# Altium schematic sheet H05R2x_Frontend 1.SchDoc("H05R2x_Frontend 1")
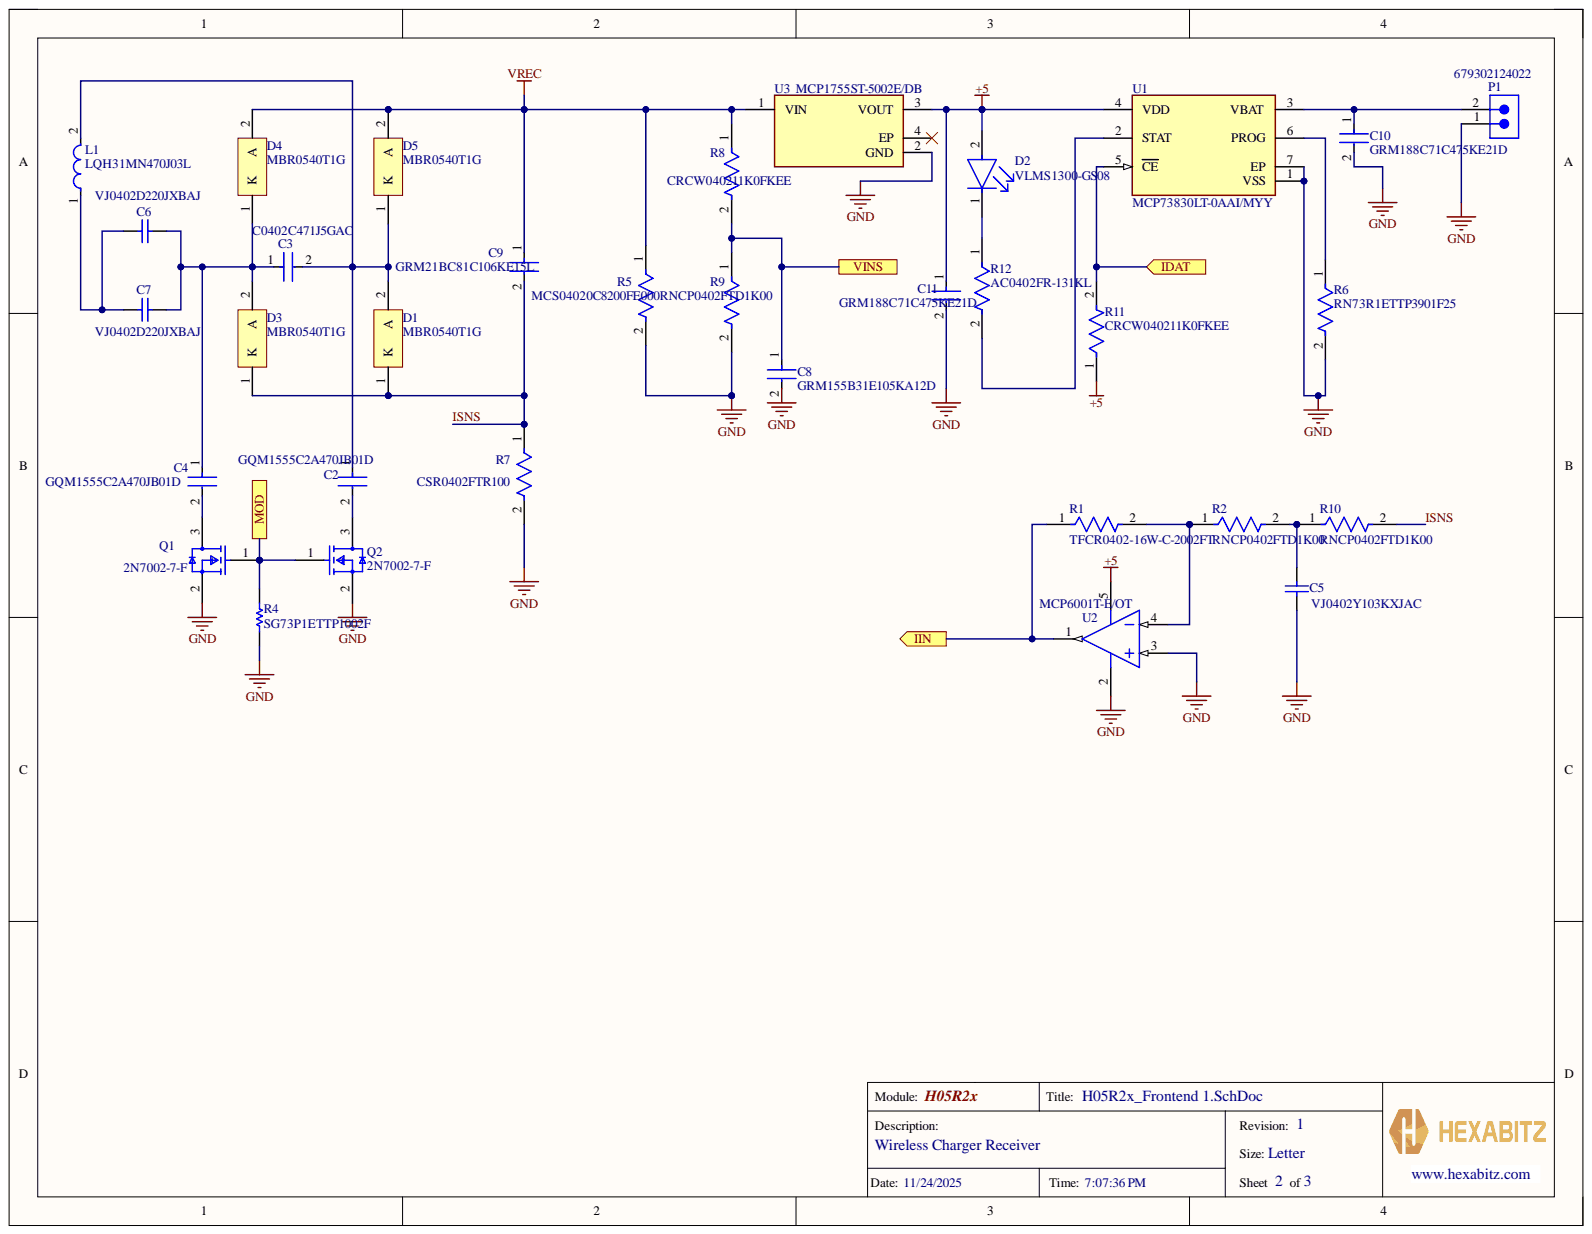
[diagram p0: H05R2x_Frontend 1.SchDoc]

## Parts
C2  GQM1555C2A470JB01D  [FP-GQM155-0_05-IPC_A]
C3  C0402C471J5GAC  [FP-C0402-BB-MFG]
C4  GQM1555C2A470JB01D  [FP-GQM155-0_05-IPC_A]
C5  VJ0402Y103KXJAC  [CAPC1005X60X26LL10T16]
C6  VJ0402D220JXBAJ  [CAPC1005X61X26LL10T16]
C7  VJ0402D220JXBAJ  [CAPC1005X61X26LL10T16]
C8  GRM155B31E105KA12D  [FP-GRM155-0_05-IPC_C]
C9  GRM21BC81C106KE15L  [FP-GRM21B-0_1-IPC_B]
C10  GRM188C71C475KE21D  [FP-GRM188-0_2-IPC_A]
C11  GRM188C71C475KE21D  [FP-GRM188-0_2-IPC_A]
D1  MBR0540T1G  [ONSC-SOD-123-2-425-04_V]
D2  VLMS1300-GS08  [FP-6_541-5092_01-4-MFG]
D3  MBR0540T1G  [ONSC-SOD-123-2-425-04_V]
D4  MBR0540T1G  [ONSC-SOD-123-2-425-04_V]
D5  MBR0540T1G  [ONSC-SOD-123-2-425-04_V]
L1  LQH31MN470J03L  [FP-LQH31CN_03-MFG]
P1  679302124022  [679302124022]
Q1  2N7002-7-F  [FP-SOT23-MFG]
Q2  2N7002-7-F  [FP-SOT23-MFG]
R1  TFCR0402-16W-C-2002FT  [FP-TFCR0402-IPC_A]
R2  RNCP0402FTD1K00  [FP-RNCP0402-IPC_C]
R4  SG73P1ETTP1002F  [RESC1005X40X25LL10T10]
R5  MCS04020C8200FE000  [FP-MCS0402-IPC_C]
R6  RN73R1ETTP3901F25  [FP-RN73R1E-IPC_C]
R7  CSR0402FTR100  [FP-CSR0402-IPC_A]
R8  CRCW040211K0FKEE  [FP-CRCW0402-e3-IPC_B]
R9  RNCP0402FTD1K00  [FP-RNCP0402-IPC_C]
R10  RNCP0402FTD1K00  [FP-RNCP0402-IPC_C]
R11  CRCW040211K0FKEE  [FP-CRCW0402-e3-IPC_B]
R12  AC0402FR-131KL  [FP-AC0402-IPC_C]
U1  MCP73830LT-0AAI/MYY  [FP-C04-078-IPC_C]
U2  MCP6001T-E/OT  [SOT-23-OT5_L]
U3  MCP1755ST-5002E/DB  [FP-C04-032-IPC_B]

## Ports
IDAT, IIN, MOD, VINS

## Nets
+5: C11.1 D2.2 R11.1 U1.4(VDD) U2.5 U3.3(VOUT)
GND: C5.2 C8.2 C10.2 C11.2 P1.1 Q1.2 Q2.2 R4.1 R5.2 R6.2 R7.2 R9.2 U1.1(VSS) U1.7(EP) U2.2 U2.3 U3.2(GND)
VREC: C9.1 D4.2 D5.2 R5.1 R8.1 U3.1(VIN)
IDAT: R11.2 U1.5(CE) ; port IDAT
IIN: R1.1 U2.1 ; port IIN
ISNS: C9.2 D1.1 D3.1 R7.1 R10.2
MOD: Q1.1 Q2.1 R4.2 ; port MOD
VINS: C8.1 R8.2 R9.1 ; port VINS
NetC2_1: C2.1 C3.2 D1.2 D5.1 L1.2
NetC2_2: C2.2 Q2.3
NetC3_1: C3.1 C4.1 C6.2 C7.2 D3.2 D4.1
NetC4_2: C4.2 Q1.3
NetC5_1: C5.1 R2.2 R10.1
NetC6_1: C6.1 C7.1 L1.1
NetC10_1: C10.1 P1.2 U1.3(VBAT)
NetD2_1: D2.1 R12.1
NetR1_2: R1.2 R2.1 U2.4
NetR6_1: R6.1 U1.6(PROG)
NetR12_2: R12.2 U1.2(STAT)

Not connected: U3 4(EP)

## Library data of the parts
C2 C4 = CMP-06035-010628-1: Ceramic Capacitor 47pF  ±5% 100VDC C0G 0402 Paper T/R; Voltage Rating: 100V; Capacitance: 47pF; Case/Package: 0402; Component Kind: Standard; Dielectric: C0G; Length: 0.039inch; Library Name: Altium Content Vault; Max Operating Temperature: 125°C; Min Operating Temperature: -55°C; Mount: Surface Mount; Packaging: Tape and Reel; Thickness: 0.022inch; Tolerance: 5%; Voltage Rating (DC): 100V; Width: 0.02inch
C3 = CMP-03020-013778-2: C0402 470 pF C0G 0.05 50 V; Manufacturer: Kemet; Capacitance: 470 pF; Material: Ceramic; Tolerance: 0.05; Value: 470 pF; Voltage Rating: 50 V; Automotive: No; Category: Cap; Component Kind: Standard; ComponentLink2Description: Datasheet; ComponentLink2URL: https://content.kemet.com/datasheets/KEM_C1002_X7R_SMD.pdf; Device Class L1: Passive Components; Device Class L2: Capacitors; Device Class L3: Ceramic Capacitors; Height: 0.55mm; Ipc Land Pattern Name: CAPC10050X50; Lead Free: Yes; Library Name: Altium Content Vault; Manufacturer Part Number: C0402C471J5GAC; Mouser Description: Cap Ceramic 470pF 50V C0G 5% Pad SMD 0402 125C Bulk; Octopart: https://octopart.com/c0402c471j5gac-kemet-29626191; Package: C0402-BB; RoHS: TRUE; Temperature: -55°C to +125°C; Temperature Characteristic: C0G; Temperature Range High: +125°C; Temperature Range Low: -55°C
C5 = CMP-2008-02025-1: Manufacturer: Vitramon; Component Kind: Standard; Library Name: Altium Content Vault; Part Number: VJ0402Y103KXJAC
C6 C7 = CMP-2008-02000-1: Manufacturer: Vitramon; Component Kind: Standard; Library Name: Altium Content Vault; Part Number: VJ0402D220JXBAJ
C8 = CMP-06035-057649-1: Chip Multilayer Ceramic Capacitors for General Purpose, 0402, 1.0uF, B, 10%, 10%, 25V; Capacitance: 1uF; Component Kind: Standard; Length: 1mm; Library Name: Altium Content Vault; Thickness: 500um; Tolerance: 10%; Voltage Rating (DC): 25V; Width: 500um
C9 = CMP-2007-03503-2: Chip Multilayer Ceramic Capacitors for General Purpose, 0805, 10uF, X6S, 22%, 10%, 16V; Capacitance: 10uF; Case Code (Imperial): 0805; Case Code (Metric): 2012; Case/Package: 0805; Component Kind: Standard; Depth: 1.25mm; Dielectric: X6S; Height: 1.25mm; Lead Free: Lead Free; Length: 2mm; Library Name: Altium Content Vault; Max Operating Temperature: 105°C; Min Operating Temperature: -55°C; Mount: Surface Mount; Package Quantity: 3000; Packaging: Tape and Reel; REACH SVHC: No SVHC; Termination: SMD/SMT; Thickness: 0.053inch; Tolerance: 10%; Voltage: 16V; Voltage Rating: 16V; Voltage Rating (DC): 16V; Weight: 0.000335oz; Width: 0.049inch
C10 C11 = CMP-06035-002362-1: Chip Multilayer Ceramic Capacitors for General Purpose, 0603, 4.7uF, X7S, 22%, 10%, 16V; Capacitance: 4.7uF; Component Kind: Standard; Dielectric: X7S; Length: 1.6mm; Library Name: Altium Content Vault; Thickness: 800um; Tolerance: 10%; Voltage Rating (DC): 16V; Width: 800um
D1 D3-D5 = CMP-1055-00401-1: Schottky Power Rectifier, 2-Pin SOD-123, Pb-Free, Tape and Reel; Manufacturer: On Semiconductor; Configuration: Single; IFSM Max (A): 5.5; Component Kind: Standard; ComponentLink1Description: Manufacturer URL; ComponentLink1URL: http://www.onsemi.com/; ComponentLink2Description: Datasheet; ComponentLink2URL: http://www.onsemi.cn/pub_link/Collateral/MBR0540T1-D.PDF; ComponentLink3Description: Package Specification; ComponentLink3URL: http://www.onsemi.com/pub_link/Collateral/425-04.PDF; DatasheetVersion: Rev. 7; Library Name: Altium Content Vault; Mounting Technology: Surface Mount; PackageDescription: 2-Pin SOD, Body 1.6 x 2.69 mm; PackageReference: SOD-123-2-425-04; PackageVersion: Rev. G, 10/2009; Packing: Tape and Reel; PartNumber: MBR0540T1G; RoHS: TRUE
D2 = CMP-02520-000012-1: LED RED 0603 SMD; Manufacturer: Vishay; Forward Current: 20mA; Forward Voltage: 2V; Led Orientation: Top View; Luminous Intensity: 54mcd; Peak Emmision Wavelength: 639nm; Power Dissipation: 75mW; Reverse Voltage: 5V; Viewing Angle: 130°; Wavelength: 631nm; Ambient Temperature Range High: +85°C; Ambient Temperature Range Low: -35°C; Automotive: No; Category: Diode; Colour: Red; Component Kind: Standard; ComponentLink2Description: Datasheet; ComponentLink2URL: http://www.vishay.com/docs/82437/vlmo1300.pdf; Device Class L1: Optoelectronics; Device Class L2: LEDs; Device Class L3: unset; Digikey Description: LED RED 0603 SMD; Height: 1mm; Lead Free: Yes; Library Name: Altium Content Vault; Manufacturer Part Number: VLMS1300-GS08; Mouser Description: Standard LEDs - SMD Super Red Clear Non-Diff; Octopart: https://octopart.com/vlms1300-gs08-vishay-21709201; Package: 6.541-5092.01-4; RoHS: TRUE; Temperature: -35°C to +85°C; Temperature Range High: +85°C; Temperature Range Low: -35°C
L1 = CMP-06042-003344-1: Wire Wound Ferrite Inductor for General Circuits 47μH ±5% 10.4Ω 55mA 1206; DC Resistance (DCR): 8R; Inductance: 47uH; Q Factor: 40; Self Resonant Frequency: 10MHz; Shielding: Unshielded; Test Frequency: 1MHz; Case Code (Imperial): 1206; Case Code (Metric): 3216; Case/Package: 1206; Component Kind: Standard; Core Material: Ferrite; Current Rating: 55mA; DC Current: 55mA; Depth: 1.8mm; Height: 1.8mm; Height - Seated (Max): 0.079inch; Length: 0.126inch; Library Name: Altium Content Vault; Max DC Current: 55mA; Max Operating Temperature: 85°C; Military Standard: Not; Min Operating Temperature: -40°C; Mount: Surface Mount; Package Quantity: 2000; Packaging: Tape and Reel; Radiation Hardening: No; Resistor Type: Wirewound; Termination: SMD/SMT; Tolerance: 5%; Weight: 0.001023oz; Width: 0.063inch
P1 = CMP-1502-00721-2: NexarPartId: 18818281; Connector Type: Male Pin; Contact Resistance: 20mΩ; Current Rating: 1A; Gender: Male; Insulation: Insulated; Insulation Resistance: 100MΩ; Number of Contacts: 2; Operating Supply Voltage: 50V; Pitch: 1.5mm; Color: Beige, White; Component Kind: Standard; Contact Gender: Male; Contact Material: Copper; Contact Plating: Tin; Flammability Rating: UL94 V-0; Height: 5mm; Housing Material: Nylon; Lead Pitch: 1.5mm; Length: 6mm; Library Name: Altium Content Vault; Max Operating Temperature: 85°C; Min Operating Temperature: -25°C; Mount: Surface Mount; Number of Pins: 2; Number of Positions: 2; Number of Rows: 1; Orientation: Vertical; Packaging: Tape and Reel; REACH SVHC: Unknown; RoHS: Compliant; Termination: SMD/SMT; Voltage Rating: 50V; Width: 6mm
Q1 Q2 = CMP-2000-04929-2: MOSFET N-CH 60V 115MA SOT23-3; Drain to Source Breakdown Voltage: 70V; Drain to Source Resistance: 7.5R; Element Configuration: Single; Fall Time: 5.6ns; Gate to Source Voltage (Vgs): 20V; Input Capacitance: 50pF; Max Power Dissipation: 300mW; Nominal Vgs: 2.5V; Number of Channels: 1; Power Dissipation: 540mW; Rise Time: 3ns; Turn-Off Delay Time: 11ns; Turn-On Delay Time: 7ns; Case/Package: SOT-23; Component Kind: Standard; Contact Plating: Tin; Continuous Drain Current (ID): 115mA; Current Rating: 115mA; Drain to Source Voltage (Vdss): 60V; Dual Supply Voltage: 60V; Height: 1mm; Lead Free: Lead Free; Length: 2.9mm; Library Name: Altium Content Vault; Max Operating Temperature: 150°C; Min Operating Temperature: -55°C; Mount: Surface Mount; Number of Elements: 1; Number of Pins: 3; On-State Resistance: 7.5R; Package Quantity: 3000; Packaging: Tape and Reel; Radiation Hardening: No; Rds On Max: 7.5R; REACH SVHC: No SVHC; Termination: SMD/SMT; Threshold Voltage: 2.5V; Voltage: 60V; Voltage Rating (DC): 60V; Weight: 0.000282oz; Width: 1.3mm
R1 = CMP-250906-149647-1: RES Thin Film,  20kΩ, 1%, 0.063W, 50ppm/°C, 0402; Component Kind: Standard; Library Name: Altium Content Vault
R2 R9 R10 = CMP-26527-002183-1: 1kΩ ±1% 0.1W 0402 High Power Anti-Sulfur Thin Film Chip Resistor; Component Kind: Standard; Library Name: Altium Content Vault; Max Operating Temperature: 155°C; Min Operating Temperature: -55°C; Mount: Surface Mount; Tolerance: 1%
R4 = CMP-2002-04584-1: Manufacturer: KOA Speer; Component Kind: Standard; Library Name: Altium Content Vault; Part Number: SG73P1ETTP1002F
R5 = CMP-02407-004026-1: RES SMD 1.2K OHM 1% 1/10W 0402; Max Power Dissipation: 100mW; Resistance: 820R; Temperature Coefficient: 50ppm/°C; Case/Package: 0402; Component Kind: Standard; Composition: Thin Film; Contact Plating: Tin; Depth: 0.5mm; Height: 0.015inch; Lead Free: Lead Free; Length: 0.039inch; Library Name: Altium Content Vault; Max Operating Temperature: 125°C; Military Standard: Not; Min Operating Temperature: -55°C; Number of Terminations: 2; Package Quantity: 10000; Packaging: Tape and Reel; Radiation Hardening: No; Tolerance: 1%; Width: 0.02inch
R6 = CMP-04015-061446-1: 3.9kΩ ±1% 0.063W, 1/16W Chip Resistor 0402 (1005 Metric) Moisture Resistant Thin Film; Component Kind: Standard; Library Name: Altium Content Vault
R7 = CMP-26527-000729-1: General Purpose Thick Film Chip Resistor, 0402, 0.1Ω, 1%, 200ppm/°C, 0.125W; Max Power Dissipation: 125mW; Resistance: 100mR; Case/Package: 0402; Component Kind: Standard; Contact Plating: Tin; Height: 0.017inch; Length: 0.039inch; Library Name: Altium Content Vault; Max Operating Temperature: 155°C; Min Operating Temperature: -55°C; Mount: Surface Mount; Number of Terminations: 2; Package Quantity: 10000; Packaging: Tape and Reel; Radiation Hardening: No; Resistor Type: Thick Film; RoHS Compliant: Yes; Series: CSR; Temperature Coefficient: 200ppm/°C; Tolerance: 1%; Width: 0.02inch
R8 R11 = CMP-02407-002513-1: RES Thick Film, 11kΩ, 1%, 0.063W, 100ppm/°C, 0402; Max Power Dissipation: 63mW; Resistance: 11kR; Case/Package: 0402; Component Kind: Standard; Composition: Thick Film; Features: Automotive AEC-Q200; Height: 0.016inch; Lead Free: Lead Free; Length: 0.039inch; Library Name: Altium Content Vault; Max Operating Temperature: 155°C; Min Operating Temperature: -55°C; Number of Terminations: 2; Package Quantity: 50000; Packaging: Tape and Reel; Temperature Coefficient: 100ppm/°C; Tolerance: 1%; Width: 0.02inch
R12 = CMP-03412-047873-1: RES 1kΩ ±1% 0.063W 0402; Component Kind: Standard; Library Name: Altium Content Vault; RoHS Compliant: Yes
U1 = CMP-06318-000013-1: IC BATT CHG LI-ION 1CELL 6TDFN; Max Supply Voltage: 6V; Min Supply Voltage: 3.75V; Component Kind: Standard; Lead Free: Lead Free; Library Name: Altium Content Vault; Max Operating Temperature: 125°C; Min Operating Temperature: -40°C; Mount: Surface Mount; Number of Pins: 6; Package Quantity: 3000; Packaging: Tape and Reel; Radiation Hardening: No; RoHS Compliant: Yes
U2 = CMP-0187-00458-3: None; Amplifier Type: GENERAL PURPOSE; Bandwidth: 1MHz; Common Mode Rejection Ratio: 60dB; Input Bias Current: 1pA; Input Offset Voltage (Vos): 4.5mV; Max Supply Voltage: 6V; Min Supply Voltage: 1.8V; Nominal Supply Current: 100uA; Number of Amplifiers: 1; Operating Supply Voltage: 5.5V; Output Current: 23mA; Power Supply Rejection Ratio (PSRR): 86dB; Slew Rate: 0.6V/µs; Voltage Gain: 112dB; Case/Package: SOT-23; Component Kind: Standard; Contact Plating: Tin; Gain Bandwidth Product: 1MHz; Height: 1.3mm; Input Offset Voltage-Max: 4.5mV; Lead Free: Lead Free; Length: 3.1mm; Library Name: Altium Content Vault; Max Operating Temperature: 125°C; Min Operating Temperature: -40°C; Mount: Surface Mount; Number of Channels: 1; Number of Circuits: 1; Number of Elements: 1; Number of Pins: 5; Operating Supply Current: 100uA; Output Current per Channel: 23mA; Package Quantity: 3000; Packaging: Tape and Reel; Radiation Hardening: No; REACH SVHC: No SVHC; RoHS Compliant: Yes; Simulation: MCP6001; Slew Rate-Nom: 0.6V/µs; Supply Current: 100uA; Technology: CMOS; Unity Gain Bandwidth Product: 1MHz; Voltage: 6V; Width: 1.8mm
U3 = CMP-06324-000149-1: IC REG LINEAR 5V 300MA SOT223-3; Accuracy: 2%; Dropout Voltage: 300mV; Max Input Voltage: 16V; Min Input Voltage: 3.6V; Min Output Voltage: 3.3V; Nominal Supply Current: 68uA; Number of Outputs: 1; Output Type: Fixed; Polarity: Positive; Power Supply Rejection Ratio (PSRR): 80dB; Quiescent Current: 100uA; Case/Package: SOT-223-3; Component Kind: Standard; Height: 1.7mm; Lead Free: Lead Free; Length: 6.7mm; Library Name: Altium Content Vault; Max Operating Temperature: 125°C; Max Output Current: 300mA; Min Operating Temperature: -40°C; Mount: Surface Mount; Nominal Output Voltage: 5V; Number of Pins: 3; Number of Regulators: 1; Output Current: 300mA; Output Voltage: 5V; Package Quantity: 1; Packaging: Tape and Reel; Reach SVHC Compliant: Yes; RoHS Compliant: Yes; Supply Current: 68uA; Width: 3.7mm
